# Altium schematic sheet SmartHomeWiFi.SchDoc(SmartHomeWiFi)
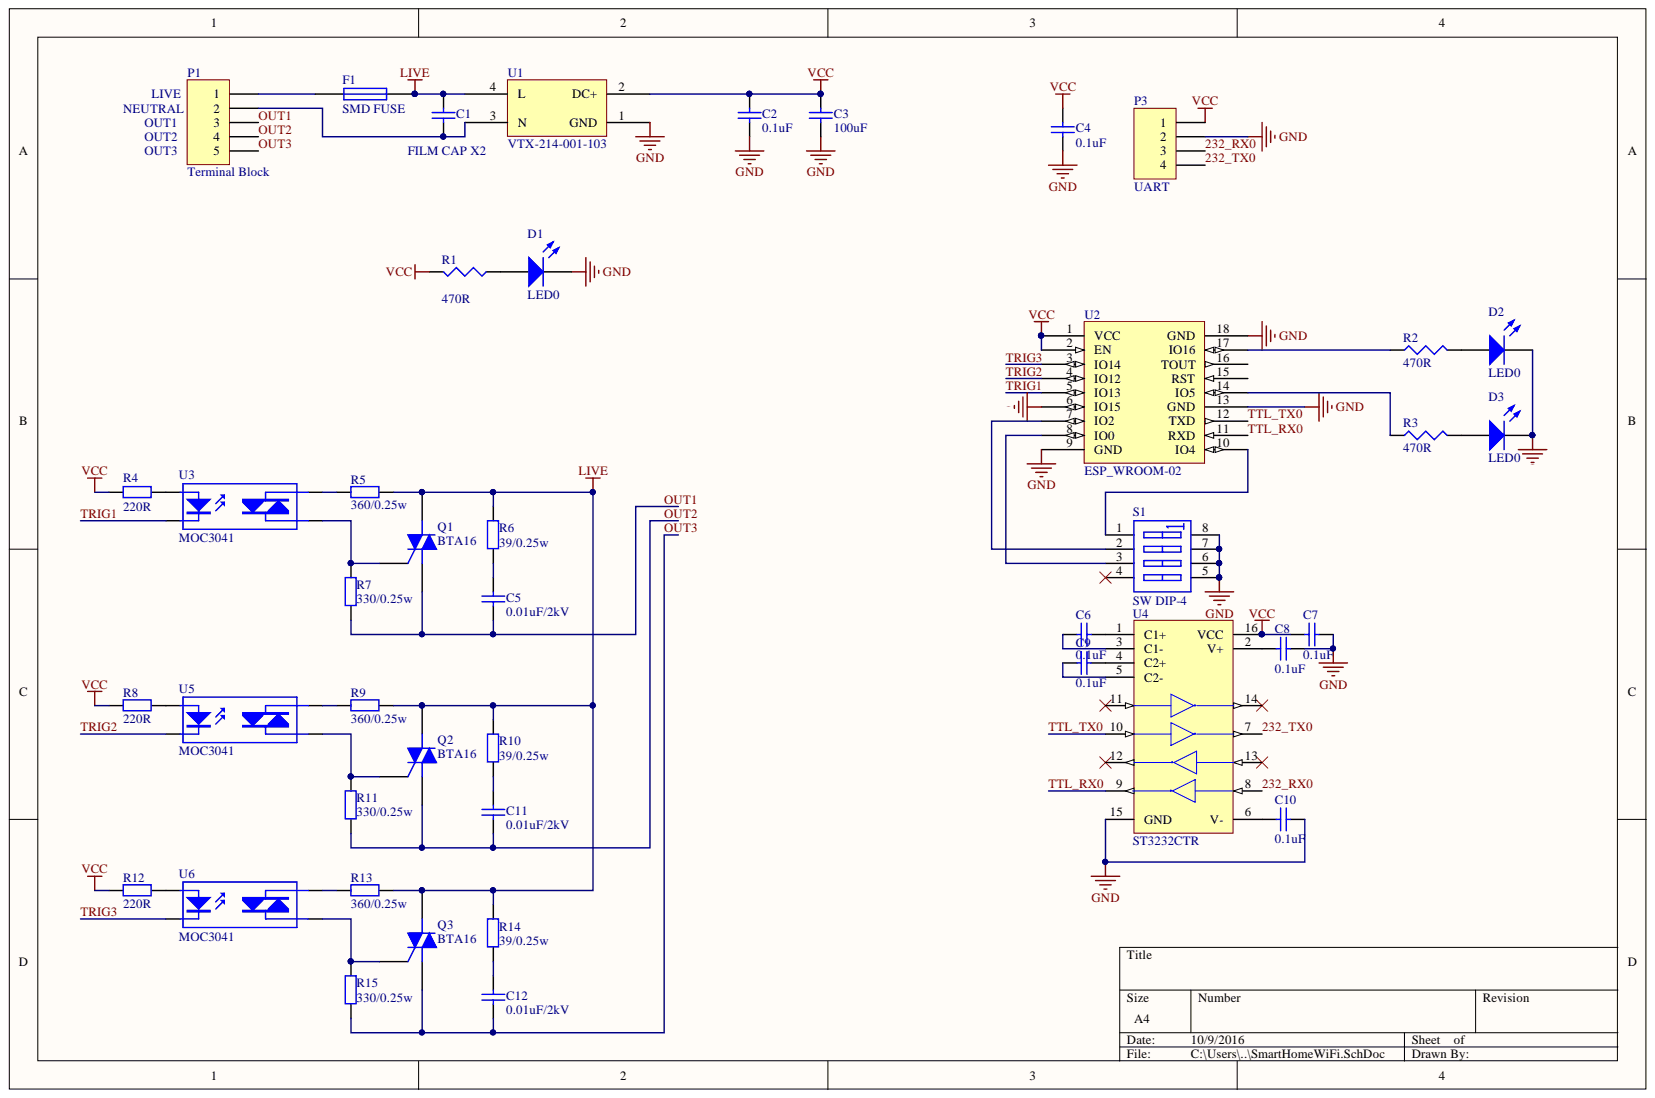
[diagram p0: SmartHomeWiFi.SchDoc]

## Parts
C1  FILM CAP X2  [Radial Leaded Cap X2]
C2  value 0.1uF  [1608[0603]]  (hidden comment: Cap Semi)
C3  value 100uF  [CAPC3225M]  (hidden comment: Cap Semi)
C4  value 0.1uF  [1608[0603]]  (hidden comment: Cap Semi)
C5  value 0.01uF/2kV  [CAPC5750M]  (hidden comment: Cap)
C6  value 0.1uF  [1608[0603]]  (hidden comment: MC0603B104M160CT)
C7  value 0.1uF  [1608[0603]]  (hidden comment: MC0603B104M160CT)
C8  value 0.1uF  [1608[0603]]  (hidden comment: MC0603B104M160CT)
C9  value 0.1uF  [1608[0603]]  (hidden comment: MC0603B104M160CT)
C10  value 0.1uF  [1608[0603]]  (hidden comment: MC0603B104M160CT)
C11  value 0.01uF/2kV  [CAPC5750M]  (hidden comment: Cap)
C12  value 0.01uF/2kV  [CAPC5750M]  (hidden comment: Cap)
D1  LED0  [1608[0603]]
D2  LED0  [1608[0603]]
D3  LED0  [1608[0603]]
F1  SMD FUSE  [SMD FUSE]
P1  Terminal Block  [Terminal Block 5 Pins]
P3  UART  [HDR1X4]
Q1  BTA16  [TO-220-AB]
Q2  BTA16  [TO-220-AB]
Q3  BTA16  [TO-220-AB]
R1  value 470R  [J1-0603]  (hidden comment: Res3)
R2  value 470R  [J1-0603]  (hidden comment: Res3)
R3  value 470R  [J1-0603]  (hidden comment: Res3)
R4  value 220R  [RESC1608M]  (hidden comment: Res2)
R5  value 360/0.25w  [RESC3216M]  (hidden comment: Res2)
R6  value 39/0.25w  [RESC3216M]  (hidden comment: Res2)
R7  value 330/0.25w  [RESC3216M]  (hidden comment: Res2)
R8  value 220R  [RESC1608M]  (hidden comment: Res2)
R9  value 360/0.25w  [RESC3216M]  (hidden comment: Res2)
R10  value 39/0.25w  [RESC3216M]  (hidden comment: Res2)
R11  value 330/0.25w  [RESC3216M]  (hidden comment: Res2)
R12  value 220R  [RESC1608M]  (hidden comment: Res2)
R13  value 360/0.25w  [RESC3216M]  (hidden comment: Res2)
R14  value 39/0.25w  [RESC3216M]  (hidden comment: Res2)
R15  value 330/0.25w  [RESC3216M]  (hidden comment: Res2)
S1  SW DIP-4  [SO8_N]
U1  VTX-214-001-103  [VTX-214-001-103]
U2  ESP_WROOM-02  [ESP-WROOM-02]
U3  MOC3041  [SMDIP6]
U4  ST3232CTR  [TSSOP16_N]
U5  MOC3041  [SMDIP6]
U6  MOC3041  [SMDIP6]

## Nets
GND: C2.2 C3.2 C4.2 C7.1 C8.1 C10.1 D1.2 D2.2 D3.2 P3.2 S1.5 S1.6 S1.7 S1.8 U1.1(GND) U2.6(IO15) U2.9(GND) U2.13(GND) U2.18(GND) U4.15(GND)
LIVE: C1.2 F1.2 Q1.2 Q2.2 Q3.2 R5.1 R6.1 R9.1 R10.1 R13.1 R14.1 U1.4(L)
VCC: C2.1 C3.1 C4.1 C7.2 P3.1 R1.2 R4.1 R8.1 R12.1 U1.2(DC+) U2.1(VCC) U2.2(EN) U4.16(VCC)
232_RX0: P3.3 U4.8
232_TX0: P3.4 U4.7
OUT1: C5.1 P1.3 Q1.1 R7.1
OUT2: C11.1 P1.4 Q2.1 R11.1
OUT3: C12.1 P1.5 Q3.1 R15.1
TRIG1: U2.5(IO13) U3.2
TRIG2: U2.4(IO12) U5.2
TRIG3: U2.3(IO14) U6.2
TTL_RX0: U2.11(RXD) U4.9
TTL_TX0: U2.12(TXD) U4.10
NetC1_1: C1.1 P1.2 U1.3(N)
NetC5_2: C5.2 R6.2
NetC6_1: C6.1 U4.1(C1+)
NetC6_2: C6.2 U4.3(C1-)
NetC8_2: C8.2 U4.2(V+)
NetC9_1: C9.1 U4.4(C2+)
NetC9_2: C9.2 U4.5(C2-)
NetC10_2: C10.2 U4.6(V-)
NetC11_2: C11.2 R10.2
NetC12_2: C12.2 R14.2
NetD1_1: D1.1 R1.1
NetD2_1: D2.1 R2.2
NetD3_1: D3.1 R3.2
NetF1_1: F1.1 P1.1
NetQ1_3: Q1.3 R7.2 U3.4
NetQ2_3: Q2.3 R11.2 U5.4
NetQ3_3: Q3.3 R15.2 U6.4
NetR2_1: R2.1 U2.17(IO16)
NetR3_1: R3.1 U2.14(IO5)
NetR4_2: R4.2 U3.1
NetR5_2: R5.2 U3.6
NetR8_2: R8.2 U5.1
NetR9_2: R9.2 U5.6
NetR12_2: R12.2 U6.1
NetR13_2: R13.2 U6.6
NetS1_1: S1.1 U2.10(IO4)
NetS1_2: S1.2 U2.7(IO2)
NetS1_3: S1.3 U2.8(IO0)

Not connected: S1 4; U2 15(RST) 16(TOUT); U4 11 12 13 14

## Library data of the parts
C1 = FILM CAP X2: CAP, FILM, PP, 100NF, 275VAC, RAD; Component Kind: Standard; Library Name: varslib.IntLib
C2-C4 C6-C10 = Cap Semi: Capacitor (Semiconductor SIM Model); Component Kind: Standard; LatestRevisionDate: 29-May-2009; LatestRevisionNote: IPC-7351 Footprint Added.; Library Name: Miscellaneous Devices.IntLib; PackageDescription: Chip Capacitor; PackageReference: C1206; Published: 8-Jun-2000; Signal Integrity: Cap Semi; Simulation: CAP; Publisher: Altium Limited
  C6-C10: Reference Site: http://my.element14.com/multicomp/mc0603b104m160ct/ceramic-capacitor-0-1uf-16v-x7r/dp/1709956
C5 C11 C12 = Cap: Capacitor; Component Kind: Standard; LatestRevisionDate: 17-Jul-2002; LatestRevisionNote: Re-released for DXP Platform.; Library Name: Miscellaneous Devices.IntLib; PackageDescription: Radial Cap, Thru-Hole; 2 Leads; 0.3 in Pin Spacing; PackageReference: RAD-0.3; Published: 8-Jun-2000; Signal Integrity: Cap; Simulation: CAP; Publisher: Altium Limited
D1-D3 = LED0: Typical INFRARED GaAs LED; Component Kind: Standard; LatestRevisionDate: 08-Jul-2005; LatestRevisionNote: Mech Layer 1 removed.; PackageDescription: LED; 2 Leads; PackageReference: LED-0; Published: 8-Jun-2000; Library Name: varslib.IntLib; Publisher: Altium Limited
F1 = SMD FUSE: FUSE, FAST ACTING, SMD, 2A; Component Kind: Standard; Library Name: varslib.IntLib
P1 = Terminal Block 5 Pin: 5 Ways Terminal Block, PCB, 5.08mm Pitch; Component Kind: Standard; Library Name: custom.IntLib
P3 = Header 4: Header, 4-Pin; Component Kind: Standard; LatestRevisionDate: 17-Jul-2002; LatestRevisionNote: Re-released for DXP Platform.; Library Name: Miscellaneous Connectors.IntLib; Signal Integrity: Connector; Publisher: Altium Limited
Q1-Q3 = BTA16: Silicon Bidirectional Triode Thyristor; Note: Pin 2 is electrically connected to Pin 4 (TAB); Component Kind: Standard; ComponentLink1Description: Manufacturer Link; ComponentLink1URL: http://www.motorola.com/; DatasheetVersion: 1999; LatestRevisionDate: 17-Jul-2002; LatestRevisionNote: Re-released for DXP Platform.; PackageDescription: TO, Thru-Hole, Vertical, Heatsink Mounted; 3 In-Line Leads; Pitch 2.28 mm; PackageReference: 369-03; Published: 29-Sep-2000; Library Name: varslib.IntLib; Publisher: Altium Limited
R1-R3 = Res3: Resistor; Component Kind: Standard; LatestRevisionDate: 29-May-2009; LatestRevisionNote: IPC-7351 Footprint Added.; Library Name: Miscellaneous Devices.IntLib; PackageDescription: Chip Resistor; PackageReference: J1-0603; Published: 8-Jun-2000; Signal Integrity: Res; Simulation: RESISTOR; Publisher: Altium Limited
R4-R15 = Res2: Resistor; Component Kind: Standard; LatestRevisionDate: 17-Jul-2002; LatestRevisionNote: Re-released for DXP Platform.; Library Name: Miscellaneous Devices.IntLib; PackageDescription: Axial Device, Thru-Hole; 2 Leads; 0.4 in Pin Spacing; PackageReference: AXIAL-0.4; Published: 8-Jun-2000; Signal Integrity: Res; Simulation: RESISTOR; Publisher: Altium Limited
S1 = SW DIP-4: DIP Switch, 4 Position, SPST; Code_IPC: SOIC127P600-8; Code_JEDEC: MS-012-AA; Component Kind: Standard; LatestRevisionDate: 29-May-2009; LatestRevisionNote: IPC-7351 Footprint Added.; Library Name: Miscellaneous Devices.IntLib; PackageDescription: 8-Pin Small Outline Integrated Circuit 1.27 mm Pitch; PackageReference: SO8; PackageVersion: Dec-2002; Published: 24-Mar-1999; Simulation: dpsw4; Publisher: Altium Limited
U1 = VTX-214-001-103: AC-DC CONV, FIXED, 1 O/P, 1W, 3.3V; Manufacturer: VIGORTRONIX; Component Kind: Standard; Library Name: varslib.IntLib
U2 = ESP-WROOM-02: ESP-WROOM-02 WiFi 802.11 Module; Component Kind: Standard; Library Name: varslib.IntLib
U3 U5 U6 = MOC3041: Opto-Triac; Component Kind: Standard; Library Name: varslib.IntLib
U4 = MAX3232CUE: 3.0V TO 5.5V, Low-Power, up to 1Mbps, True RS-232 Transceiver Using Four 0.1µF External Capacitor; Component Kind: Standard; ComponentLink1Description: Manufacturer Link; ComponentLink1URL: http://www.maxim-ic.com/; ComponentLink2Description: Datasheet; ComponentLink2URL: http://pdfserv.maxim-ic.com/en/ds/MAX3222-MAX3241.pdf; DatasheetVersion: Mar-1999; LatestRevisionDate: 29-May-2009; LatestRevisionNote: IPC-7351 Footprint Added.; Library Name: Maxim Communication Transceiver.IntLib; PackageDescription: 16-Pin Thin Small Outline Package 0.65 mm Pitch; PackageReference: TSSOP16; PackageVersion: 21-0066 C; PCB3D: TSSOP16; Published: 16-Jan-2001; Reference Site: http://my.element14.com/stmicroelectronics/st3232ctr/ic-driver-receiver-rs232-tssop16/dp/1366572; Publisher: Altium Limited
